# Altium schematic sheet Sheet2_2.SchDoc
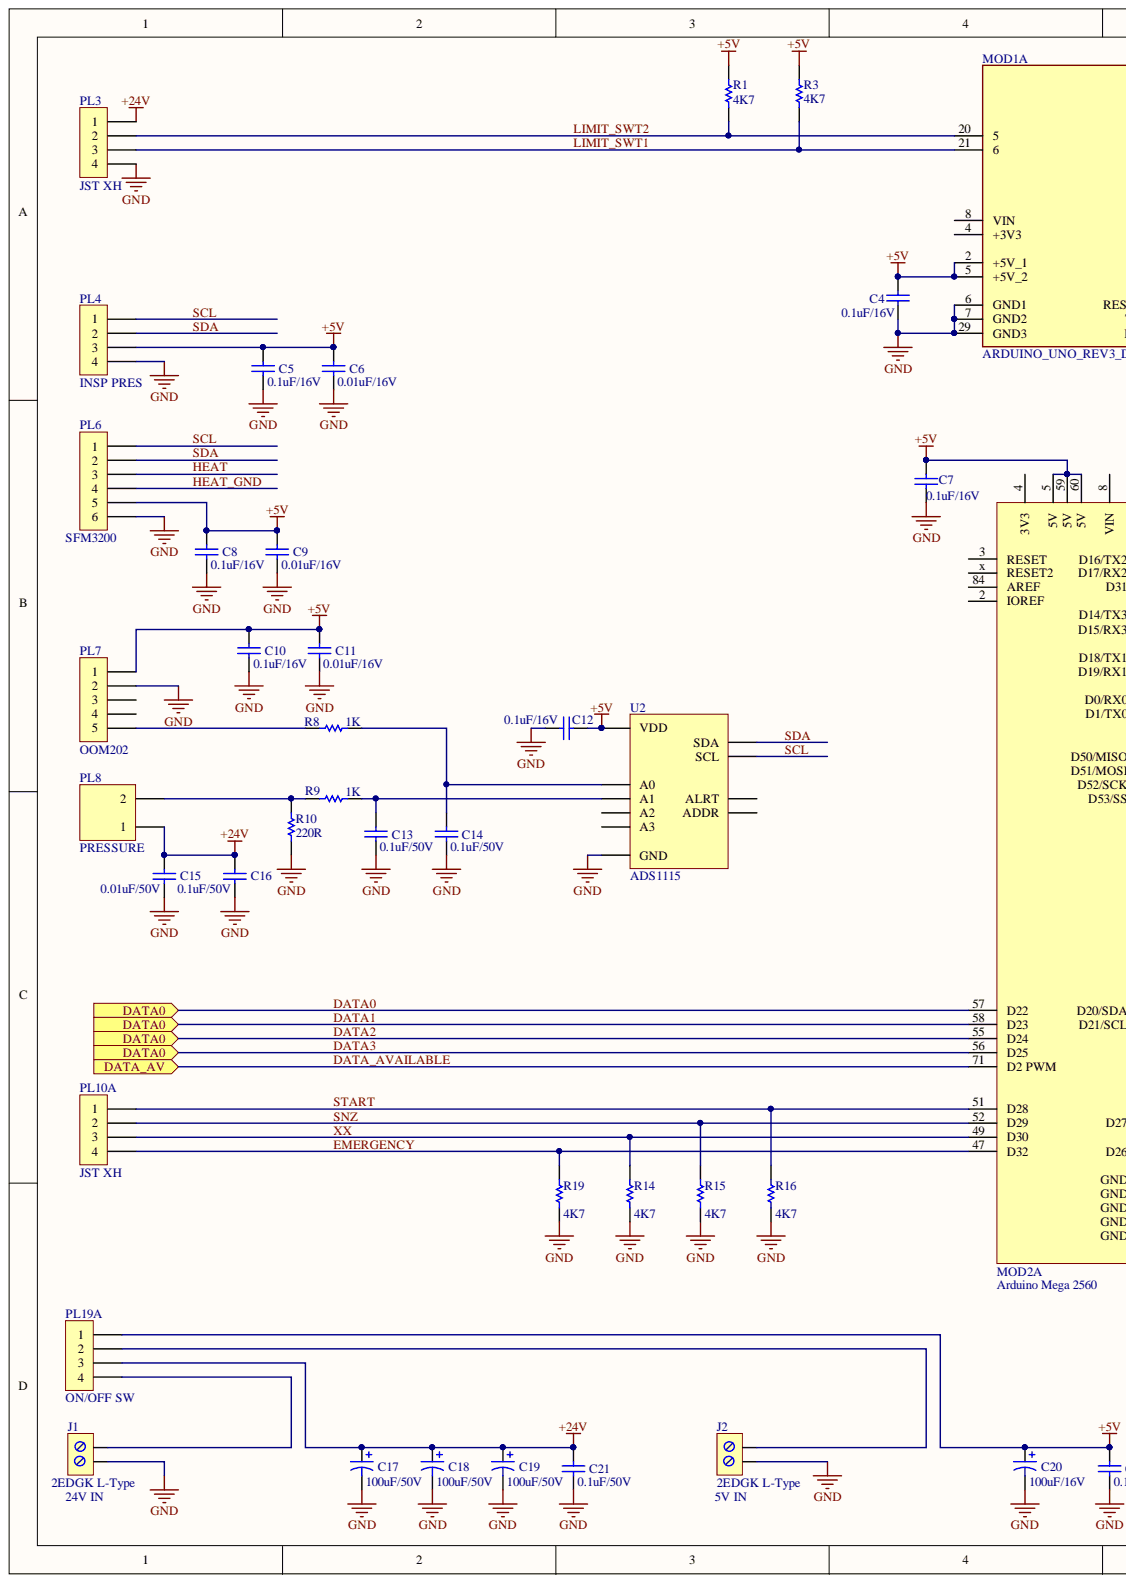
[diagram p0: Sheet2_2.SchDoc, left]
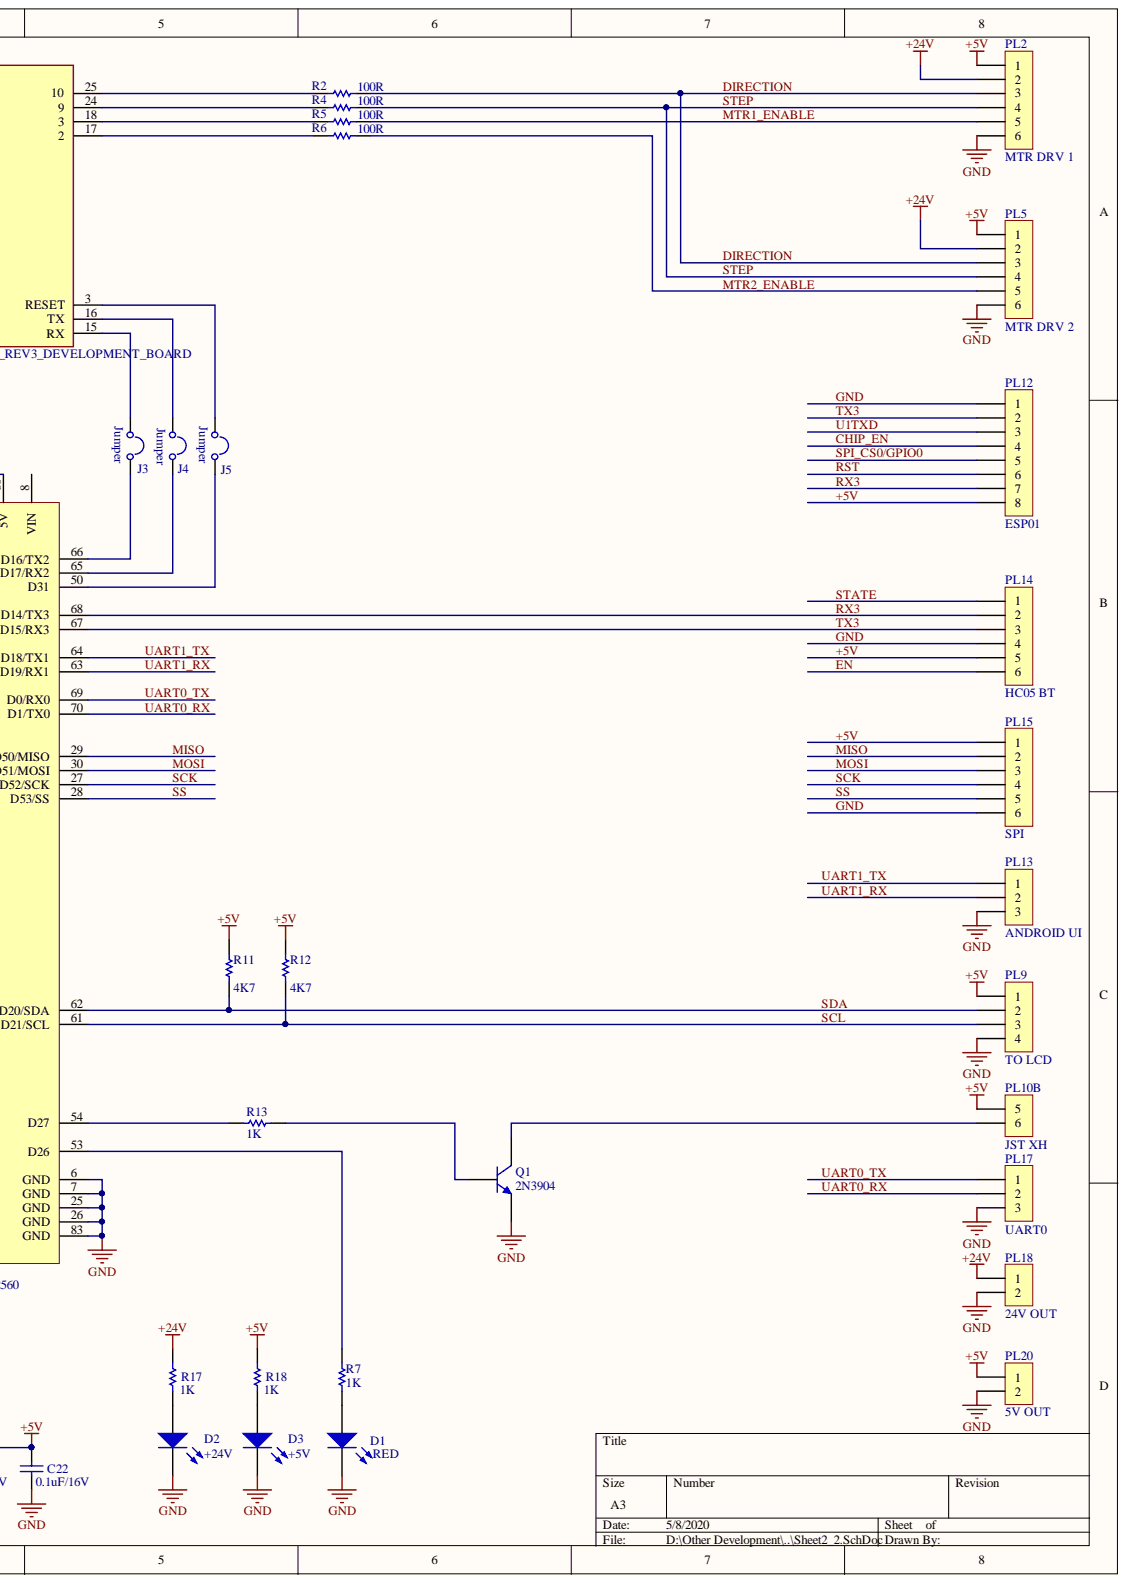
[diagram p1: Sheet2_2.SchDoc, right]

## Parts
C4  value 0.1uF/16V  [CapRad]  (hidden comment: Cap)
C5  value 0.1uF/16V  [CapRad]  (hidden comment: Cap)
C6  value 0.01uF/16V  [CapRad]  (hidden comment: Cap)
C7  value 0.1uF/16V  [CapRad]  (hidden comment: Cap)
C8  value 0.1uF/16V  [CapRad]  (hidden comment: Cap)
C9  value 0.01uF/16V  [CapRad]  (hidden comment: Cap)
C10  value 0.1uF/16V  [CapRad]  (hidden comment: Cap)
C11  value 0.01uF/16V  [CapRad]  (hidden comment: Cap)
C12  value 0.1uF/16V  [CapRad]  (hidden comment: Cap)
C13  value 0.1uF/50V  [CapRad]  (hidden comment: Cap)
C14  value 0.1uF/50V  [CapRad]  (hidden comment: Cap)
C15  value 0.1uF/50V  [CapRad]  (hidden comment: Cap)
C16  Cap  [CapRad]  (value not drawn)
C17  value 100uF/50V  [CapPol200]  (hidden comment: Cap Pol1)
C18  value 100uF/50V  [CapPol200]  (hidden comment: Cap Pol1)
C19  value 100uF/50V  [CapPol200]  (hidden comment: Cap Pol1)
C20  value 100uF/16V  [CapPol200]  (hidden comment: Cap Pol1)
C21  value 0.1uF/50V  (hidden comment: Cap)
C22  value 0.1uF/16V  [CapRad]  (hidden comment: Cap)
D1  RED  [LED-0]
D2  +24V  [LED-0]
D3  +5V  [LED-0]
J1  2EDGK L-Type
J2  2EDGK L-Type
J3  Jumper
J4  Jumper
J5  Jumper
MOD1  ARDUINO_UNO_REV3_DEVELOPMENT_BOARD  [ARDUINO_UNO_REV3_DEVELOPMENT_BOARD]  (part A)
MOD2  Arduino Mega 2560  (part A)
PL2  MTR DRV 1
PL3  JST XH
PL4  INSP PRES
PL5  MTR DRV 2
PL6  SFM3200
PL7  OOM202
PL8  PRESSURE
PL9  TO LCD
PL10  JST XH  (parts A,B)
PL12  ESP01
PL13  ANDROID UI
PL14  HC05 BT
PL15  SPI
PL17  UART0
PL18  24V OUT
PL19  ON/OFF SW  (part A)
PL20  5V OUT
Q1  2N3904  [TO-92A]
R1  value 4K7  [AXIAL-0.3]  (hidden comment: Res1)
R2  value 100R  [AXIAL-0.3]  (hidden comment: Res1)
R3  value 4K7  [AXIAL-0.3]  (hidden comment: Res1)
R4  value 100R  [AXIAL-0.3]  (hidden comment: Res1)
R5  value 100R  [AXIAL-0.3]  (hidden comment: Res1)
R6  value 100R  [AXIAL-0.3]  (hidden comment: Res1)
R7  value 1K  [AXIAL-0.3]  (hidden comment: Res1)
R8  value 1K  [AXIAL-0.3]  (hidden comment: Res1)
R9  value 1K  [AXIAL-0.3]  (hidden comment: Res1)
R10  value 220R  [AXIAL-0.3]  (hidden comment: Res1)
R11  value 4K7  [AXIAL-0.3]  (hidden comment: Res1)
R12  value 4K7  [AXIAL-0.3]  (hidden comment: Res1)
R13  value 1K  [AXIAL-0.3]  (hidden comment: Res1)
R14  value 4K7  [AXIAL-0.3]  (hidden comment: Res1)
R15  value 4K7  [AXIAL-0.3]  (hidden comment: Res1)
R16  value 4K7  [AXIAL-0.3]  (hidden comment: Res1)
R17  value 1K  [AXIAL-0.3]  (hidden comment: Res1)
R18  value 1K  [AXIAL-0.3]  (hidden comment: Res1)
R19  value 4K7  [AXIAL-0.3]  (hidden comment: Res1)
U2  ADS1115

## Ports
DATA0, DATA_AV

## Nets
+24V: C15.2 C16.2 C17.1 C18.1 C19.1 C21.2 PL2.2 PL3.1 PL5.2 PL8.1 PL18.1 PL19.3 R17.2
+5V: C4.1 C5.2 C6.2 C7.2 C8.2 C9.2 C10.2 C11.2 C12.2 C20.1 C22.2 MOD1.2(+5V_1) MOD1.5(+5V_2) MOD2.5(5V) MOD2.59(5V) MOD2.60(5V) PL2.1 PL4.3 PL5.1 PL6.5 PL7.1 PL9.1 PL10.5 PL12.8 PL14.5 PL15.1 PL19.1 PL20.1 R1.1 R3.1 R11.1 R12.1 R18.2 U2.A4(VDD)
CHIP_EN: PL12.4
DATA0: MOD2.55(D24) MOD2.56(D25) MOD2.57(D22) MOD2.58(D23) ; port DATA0
DATA_AVAILABLE: MOD2.71(D2_PWM) ; port DATA_AV
DIRECTION: PL2.3 PL5.3 R2.2
EMERGENCY: MOD2.47(D32) PL10.4 R19.1
EN: PL14.6
GND: C4.2 C5.1 C6.1 C7.1 C8.1 C9.1 C10.1 C11.1 C12.1 C13.1 C14.1 C15.1 C16.1 C17.2 C18.2 C19.2 C20.2 C21.1 C22.1 D1.2 D2.2 D3.2 J1.2 J2.2 MOD1.6(GND1) MOD1.7(GND2) MOD1.29(GND3) MOD2.6(GND) MOD2.7(GND) MOD2.25(GND) MOD2.26(GND) MOD2.83(GND) PL2.6 PL3.4 PL4.4 PL5.6 PL6.6 PL7.2 PL9.4 PL12.1 PL13.3 PL14.4 PL15.6 PL17.3 PL18.2 PL20.2 Q1.1 R10.1 R14.2 R15.2 R16.2 R19.2 U2.A5(GND)
HEAT: PL6.3
HEAT_GND: PL6.4
LIMIT_SWT1: MOD1.21 PL3.3 R3.2
LIMIT_SWT2: MOD1.20 PL3.2 R1.2
MISO: MOD2.29(D50/MISO) PL15.2
MOSI: MOD2.30(D51/MOSI) PL15.3
MTR1_ENABLE: PL2.5 R5.2
MTR2_ENABLE: PL5.5 R6.2
RST: PL12.6
RX3: MOD2.68(D14/TX3) PL12.7 PL14.2
SCK: MOD2.27(D52/SCK) PL15.4
SCL: MOD2.61(D21/SCL) PL4.1 PL6.1 PL9.3 R12.2 U2.SCL
SDA: MOD2.62(D20/SDA) PL4.2 PL6.2 PL9.2 R11.2 U2.SDA
SNZ: MOD2.52(D29) PL10.2 R15.1
SPI_CS0/GPIO0: PL12.5
SS: MOD2.28(D53/SS) PL15.5
START: MOD2.51(D28) PL10.1 R16.1
STATE: PL14.1
STEP: PL2.4 PL5.4 R4.2
TX3: MOD2.67(D15/RX3) PL12.2 PL14.3
U1TXD: PL12.3
UART0_RX: MOD2.70(D1/TX0) PL17.2
UART0_TX: MOD2.69(D0/RX0) PL17.1
UART1_RX: MOD2.63(D19/RX1) PL13.2
UART1_TX: MOD2.64(D18/TX1) PL13.1
XX: MOD2.49(D30) PL10.3 R14.1
NetC13_2: C13.2 R9.2 U2.A1
NetC14_2: C14.2 R8.2 U2.A0
NetD1_1: D1.1 R7.1
NetD2_1: D2.1 R17.1
NetD3_1: D3.1 R18.1
NetJ1_1: J1.1 PL19.4
NetJ2_1: J2.1 PL19.2
NetJ3_1: J3.1 MOD1.15(RX)
NetJ3_2: J3.2 MOD2.66(D16/TX2)
NetJ4_1: J4.1 MOD1.16(TX)
NetJ4_2: J4.2 MOD2.65(D17/RX2)
NetJ5_1: J5.1 MOD1.3(RESET)
NetJ5_2: J5.2 MOD2.50(D31)
NetMOD1_17: MOD1.17 R6.1
NetMOD1_18: MOD1.18 R5.1
NetMOD1_24: MOD1.24 R4.1
NetMOD1_25: MOD1.25 R2.1
NetMOD2_53: MOD2.53(D26) R7.2
NetMOD2_54: MOD2.54(D27) R13.2
NetPL7_5: PL7.5 R8.1
NetPL8_2: PL8.2 R9.1 R10.2
NetPL10_6: PL10.6 Q1.3
NetQ1_2: Q1.2 R13.1

Not connected: MOD1 4(+3V3) 8(VIN); MOD2 2(IOREF) 3(RESET) 4(3V3) 8(VIN) 84(AREF) x(RESET2); PL7 3 4; U2 A2 A3 ADDR ALRT

## Library data of the parts
C4-C15 C21 C22 = Cap: Non Polar Capacitor; Component Kind: Standard; LatestRevisionDate: 17-Jul-2002; LatestRevisionNote: Re-released for DXP Platform.; Library Name: Miscellaneous Devices.IntLib; PackageDescription: Radial Cap, Thru-Hole; 2 Leads; 0.3 in Pin Spacing; PackageReference: RAD-0.3; Published: 8-Jun-2000; Publisher: Altium Limited
  C4-C15 C22: Signal Integrity: Cap; Simulation: CAP
C16 = Cap: Non Polar Capacitor; Value: 0.01uF/50V; Component Kind: Standard; LatestRevisionDate: 17-Jul-2002; LatestRevisionNote: Re-released for DXP Platform.; Library Name: Miscellaneous Devices.IntLib; PackageDescription: Radial Cap, Thru-Hole; 2 Leads; 0.3 in Pin Spacing; PackageReference: RAD-0.3; Published: 8-Jun-2000; Signal Integrity: Cap; Simulation: CAP; Publisher: Altium Limited
C17-C20 = Cap Pol1: Polarized Capacitor (Radial); Component Kind: Standard; LatestRevisionDate: 17-Jul-2002; LatestRevisionNote: Re-released for DXP Platform.; Library Name: Miscellaneous Devices.IntLib; PackageDescription: Polarized Capacitor (Radial); 2 Leads; PackageReference: RB7.6-15; Published: 8-Jun-2000; Publisher: Altium Limited
  C18-C20: Signal Integrity: Cap Pol; Simulation: CAP
D1-D3 = LED0: 5mm RED LED; Component Kind: Standard; LatestRevisionDate: 08-Jul-2005; LatestRevisionNote: Mech Layer 1 removed.; Library Name: Miscellaneous Devices.IntLib; PackageDescription: LED; 2 Leads; PackageReference: LED-0; Published: 8-Jun-2000; Simulation: LED0; Publisher: Altium Limited
MOD1 = ARDUINO_UNO_REV3_DEVELOPMENT_BOARD: Plated Through Hole Protoboard Arduino Mega Platform Evaluation Expansion Board; MP: ARDUINO UNO REV3 DEVELOPMENT BOARD; Availability: Unavailable; Component Kind: Standard; Library Name: Schlib1.SchLib; MF: Arduino; Package: None; Price: None; SnapEDA_Link: https://www.snapeda.com/parts/ARDUINO%20UNO%20REV3%20DEVELOPMENT%20BOARD/Arduino/view-part/424299/?ref=snap
PL2 PL5 PL6 PL14 PL15 = JST XH 2.54: 6 PIN CONNECTOR; Component Kind: Standard; Library Name: Schlib1.SchLib
PL3 PL4 PL9 = JST XH 2.54_4: 4 Pin Connector; Component Kind: Standard; Library Name: Schlib1.SchLib
PL7 = JST XH 2.54_5_1: 5 Pin Connector; Component Kind: Standard; Library Name: Schlib1.SchLib
PL8 = JST XH 2.54_2: 2 PIN CONNECTOR; Component Kind: Standard; Library Name: Schlib1.SchLib
PL10 PL19 = JST XH 2.54_6_2: 6 Pin Connector; Component Kind: Standard; Library Name: Schlib1.SchLib
PL12 = JST XH 2.54_5: 8 Pin Connector; Component Kind: Standard; Library Name: Schlib1.SchLib
PL13 PL17 = JST XH 2.54_3: 3 PIN CONNECTOR; Component Kind: Standard; Library Name: Schlib1.SchLib
PL18 PL20 = JST XH 2.54_1: Component Kind: Standard; Library Name: Schlib1.SchLib
Q1 = 2N3904: NPN General Purpose Transistor; Component Kind: Standard; ComponentLink1Description: Manufacturer Link; ComponentLink1URL: http://www.fairchildsemi.com/; ComponentLink2Description: Datasheet; ComponentLink2URL: http://www.fairchildsemi.com/ds/2N%2F2N3904.pdf; DatasheetVersion: 1997; LatestRevisionDate: 15-Jan-2003; LatestRevisionNote: PCB Footprint 'BCY-W3/D4.7' replaced; Library Name: Miscellaneous Devices.IntLib; PackageDescription: TO, Flat Index; 3 In-Line, Axial Leads; Body Dia. 4.5mm; Leads 0.51 x 0.48 mm (max); PackageReference: TO-92A; PackageVersion: Sep-1998; Published: 8-Jun-2000; Signal Integrity: 2N3904; Simulation: 2N3904; Publisher: Altium Limited
R1-R6 R8-R19 = Res1: Resistor 0.25W Axial Through Hole; Component Kind: Standard; LatestRevisionDate: 17-Jul-2002; LatestRevisionNote: Re-released for DXP Platform.; Library Name: Miscellaneous Devices.IntLib; PackageDescription: Axial Device, Thru-Hole; 2 Leads; 0.3 in Pin Spacing; PackageReference: AXIAL-0.3; Published: 8-Jun-2000; Signal Integrity: Res; Simulation: RESISTOR; Publisher: Altium Limited
R7 = Res1: Resistor; Component Kind: Standard; LatestRevisionDate: 17-Jul-2002; LatestRevisionNote: Re-released for DXP Platform.; Library Name: Miscellaneous Devices.IntLib; PackageDescription: Axial Device, Thru-Hole; 2 Leads; 0.3 in Pin Spacing; PackageReference: AXIAL-0.3; Published: 8-Jun-2000; Signal Integrity: Res; Simulation: RESISTOR; Publisher: Altium Limited
J1 J2 = 2EDGK L-Type: Component Kind: Standard; Library Name: Schlib1.SchLib
J3-J5 = Jumper: Component Kind: Standard; Library Name: Schlib1.SchLib
MOD2 = Arduino Mega 2560: Component Kind: Standard; Library Name: Schlib1.SchLib
U2 = ADS1115: Component Kind: Standard; Library Name: Schlib1.SchLib
